# Altium schematic sheet Regulator.SchDoc
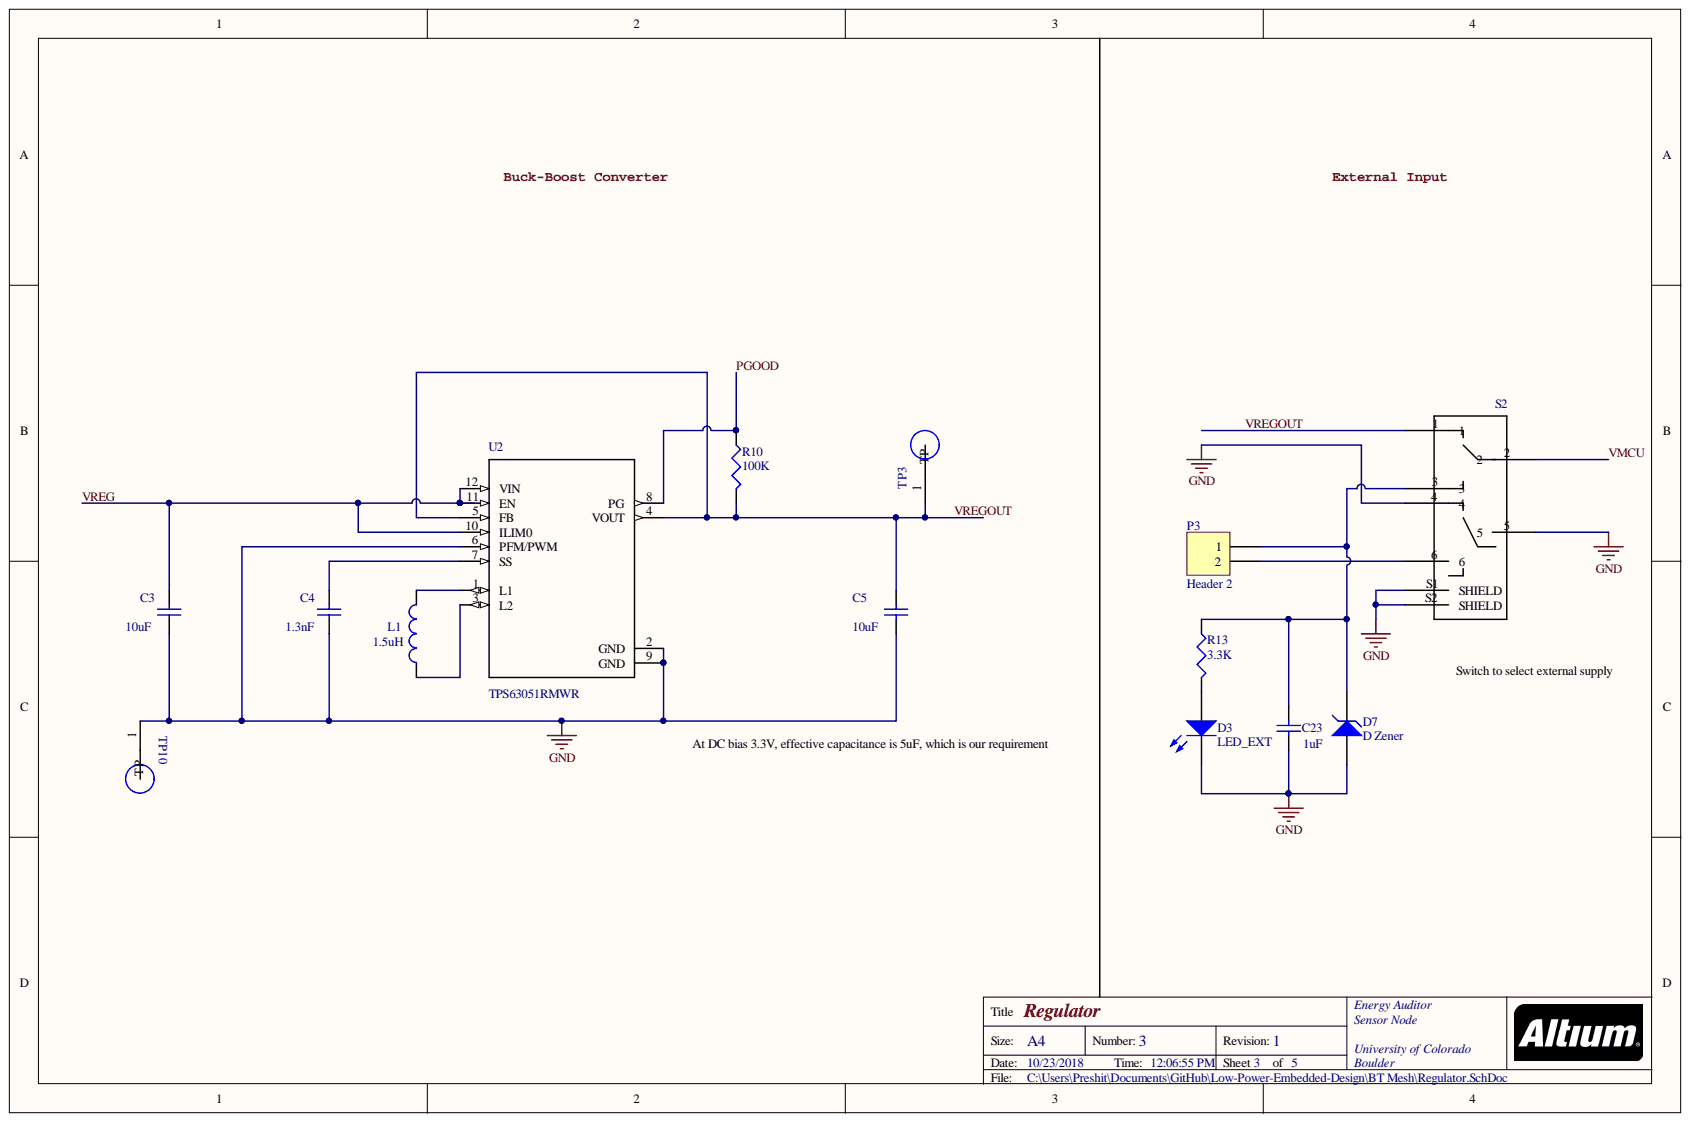
[diagram p0: Regulator.SchDoc]

## Parts
C3  10uF  [1608[0603]]
C4  1.3nF  [1608[0603]]
C5  10uF  [1608[0603]]
C23  1uF  [1608[0603]]
D3  LED_EXT  [3.2X1.6X1.1]
D7  D Zener  [0402]
L1  1.5uH  [0603]
P3  Header 2  [HDR1X2]
R10  100K  [1608[0603]]
R13  3.3K  [1608[0603]]
S2  1825289-1  [SW_1825289-1_1825289-1(Primary)]  (value not drawn)
TP3  5019  [TP380X203]  (value not drawn)
TP10  5019  [TP380X203]  (value not drawn)
U2  TPS63051RMWR  [QFN50P250X250X100-12N]

## Nets
GND: C3.1 C4.1 C5.1 C23.1 D3.2 D7.1 S2.4 S2.5 S2.S1(SHIELD) S2.S2(SHIELD) TP10.1 U2.2(GND) U2.6(PFM/PWM) U2.9(GND)
PGOOD: R10.1 U2.8(PG)
VMCU: S2.2
VREG: C3.2 U2.10(ILIM0) U2.11(EN) U2.12(VIN)
VREGOUT: C5.2 R10.2 S2.1 TP3.1 U2.4(VOUT) U2.5(FB)
NetC4_2: C4.2 U2.7(SS)
NetC23_2: C23.2 D7.2 P3.1 R13.2 S2.3
NetD3_1: D3.1 R13.1
NetL1_1: L1.1 U2.3(L2)
NetL1_2: L1.2 U2.1(L1)
NetP3_2: P3.2 S2.6

## Library data of the parts
C3 C5 = Cap: CAP CER 10UF 10V X5R 0603; Manufacturer: Murata Electronics North America; Manufacturer Part Number: GRM188R61A106KE69D; Component Kind: Standard; ComponentLink1Description: Digi-Key Link; ComponentLink1URL: https://www.digikey.com/products/en?keywords=490-10474-1-ND; Digi-Key Part Number: 490-10474-1-ND; Library Name: Miscellaneous Devices.IntLib; Signal Integrity: Cap; Simulation: CAP; Value: 10uF
C4 = Cap: CAP CER 1300PF 25V C0G/NP0 0603; Manufacturer: KEMET; Manufacturer Part Number: C0603C132J3GAC7867; Component Kind: Standard; ComponentLink1Description: Digi-Key Link; ComponentLink1URL: https://www.digikey.com/product-detail/en/kemet/C0603C132J3GAC7867/399-14953-1-ND/7382502; Digi-Key Part Number: 399-14953-1-ND; Library Name: Miscellaneous Devices.IntLib; Signal Integrity: Cap; Simulation: CAP; Value: 1.3nF
C23 = Cap: CAP CER 1UF 16V X7R 0603; Manufacturer: Samsung Electro-Mechanics; Manufacturer Part Number: CL10B105MO8NNWC; Component Kind: Standard; ComponentLink1Description: Digi-Key Link; ComponentLink1URL: https://www.digikey.com/product-detail/en/samsung-electro-mechanics/CL10B105MO8NNWC/1276-6524-1-ND/5961383; Digi-Key Part Number: 1276-6524-1-ND; Library Name: Miscellaneous Devices.IntLib; Signal Integrity: Cap; Simulation: CAP; Value: 1uF
D3 = LED2: LED GREEN DIFFUSED 0603 SMD; Manufacturer: OSRAM Opto Semiconductors Inc.; Manufacturer Part Number: LG L29K-F2J1-24-Z; Component Kind: Standard; ComponentLink1Description: Digi-Key Link; ComponentLink1URL: https://www.digikey.com/product-detail/en/osram-opto-semiconductors-inc/LG-L29K-F2J1-24-Z/475-3118-1-ND/4899549; Digi-Key Part Number: 475-3118-1-ND; Library Name: Miscellaneous Devices.IntLib; Simulation: LED2
D7 = D Zener: TVS DIODE 5.5V 14V 2X1SON; Manufacturer: Texas Instruments; Manufacturer Part Number: TPD1E10B06DPYR; Component Kind: Standard; ComponentLink1Description: Digi-Key Link; ComponentLink1URL: https://www.digikey.com/product-detail/en/texas-instruments/TPD1E10B06DPYR/296-30406-1-ND/3283560; Digi-Key Part Number: 296-30406-1-ND; Library Name: Miscellaneous Devices.IntLib; Signal Integrity: D Zener; Simulation: ZENER
L1 = Inductor: FIXED IND 1.5UH 1.1A 213 MOHM; Manufacturer: Murata Electronics North America; Manufacturer Part Number: LQM18PN1R5MFHD; Component Kind: Standard; ComponentLink1Description: Digi-Key Link; ComponentLink1URL: https://www.digikey.com/product-detail/en/murata-electronics-north-america/LQM18PN1R5MFHD/490-15637-1-ND/6800302; Digi-Key Part Number: 490-15637-1-ND; Library Name: Miscellaneous Devices.IntLib; Signal Integrity: Inductor; Simulation: INDUCTOR; Value: 1.5uH
P3 = Header 2: Header, 2-Pin; Component Kind: Standard; Library Name: Miscellaneous Connectors.IntLib; Signal Integrity: Connector
R10 = Res3: RES SMD 100K OHM 0.1% 1/10W 0603; Manufacturer: Susumu; Manufacturer Part Number: RG1608P-104-B-T5; Component Kind: Standard; ComponentLink1Description: Digi-Key Link; ComponentLink1URL: https://www.digikey.com/products/en/resistors/chip-resistor-surface-mount/52?k=RG1608P-104-B-T5&k=&pkeyword=RG1608P-104-B-T5&pv7=2&sf=0&quantity=&ColumnSort=0&page=1&pageSize=25; Digi-Key Part Number: RG16P100KBCT-ND; Library Name: Miscellaneous Devices.IntLib; Signal Integrity: Res; Simulation: RESISTOR; Value: 100K
R13 = Res3: RES SMD 3.3K OHM 5% 1/10W 0603; Manufacturer: Panasonic Electronic Components; Manufacturer Part Number: ERJ-3GEYJ332V; Component Kind: Standard; ComponentLink1Description: Digi-Key Link; ComponentLink1URL: https://www.digikey.com/product-detail/en/panasonic-electronic-components/ERJ-3GEYJ332V/P3.3KGCT-ND/135146; Digi-Key Part Number: P3.3KGCT-ND; Library Name: Miscellaneous Devices.IntLib; Signal Integrity: Res; Simulation: RESISTOR; Value: 3.3K
S2 = 1825289-1: MHS22204=DPDT SL SW VERT AG; Manufacturer: TE Connectivity; Component Kind: Standard; ComponentLink1Description: Digi-Key Link; ComponentLink1URL: https://www.digikey.com/products/en?keywords=MHS22204; Digi-Key Part Number: 450-1572-ND; Library Name: 1825289-1.SchLib; Manufacturer Part Number: 1825289-1
TP3 TP10 = 5019: Phosphor Bronze Contact Miniature Silver Plated Surface Mount Test Points; Manufacturer: Keystone; Component Kind: Standard; ComponentLink1Description: Digi-Key Link; ComponentLink1URL: https://www.digikey.com/product-detail/en/keystone-electronics/5019/36-5019CT-ND/3907344?utm_source=snapeda&utm_medium=aggregator&utm_campaign=symbol; Digi-Key Part Number: 36-5019CT-ND; Library Name: 5019.IntLib; Manufacturer Part Number: 5019
U2 = TPS63051RMWR: Tiny Single Inductor Buck Boost Converter 12-VQFN -40 to 85; Manufacturer: Texas Instruments; Component Kind: Standard; ComponentLink1Description: Digi-Key Link; ComponentLink1URL: https://www.digikey.com/products/en/integrated-circuits-ics/pmic-voltage-regulators-dc-dc-switching-regulators/739?k=TPS63051RMWR&k=&pkeyword=TPS63051RMWR&pv7=2&sf=0&quantity=&ColumnSort=0&page=1&pageSize=25; Digi-Key Part Number: 296-42650-1-ND; Library Name: TPS63051RMWR.IntLib; Manufacturer Part Number: TPS63051RMWR
